# Altium schematic sheet USB.SchDoc(USB)
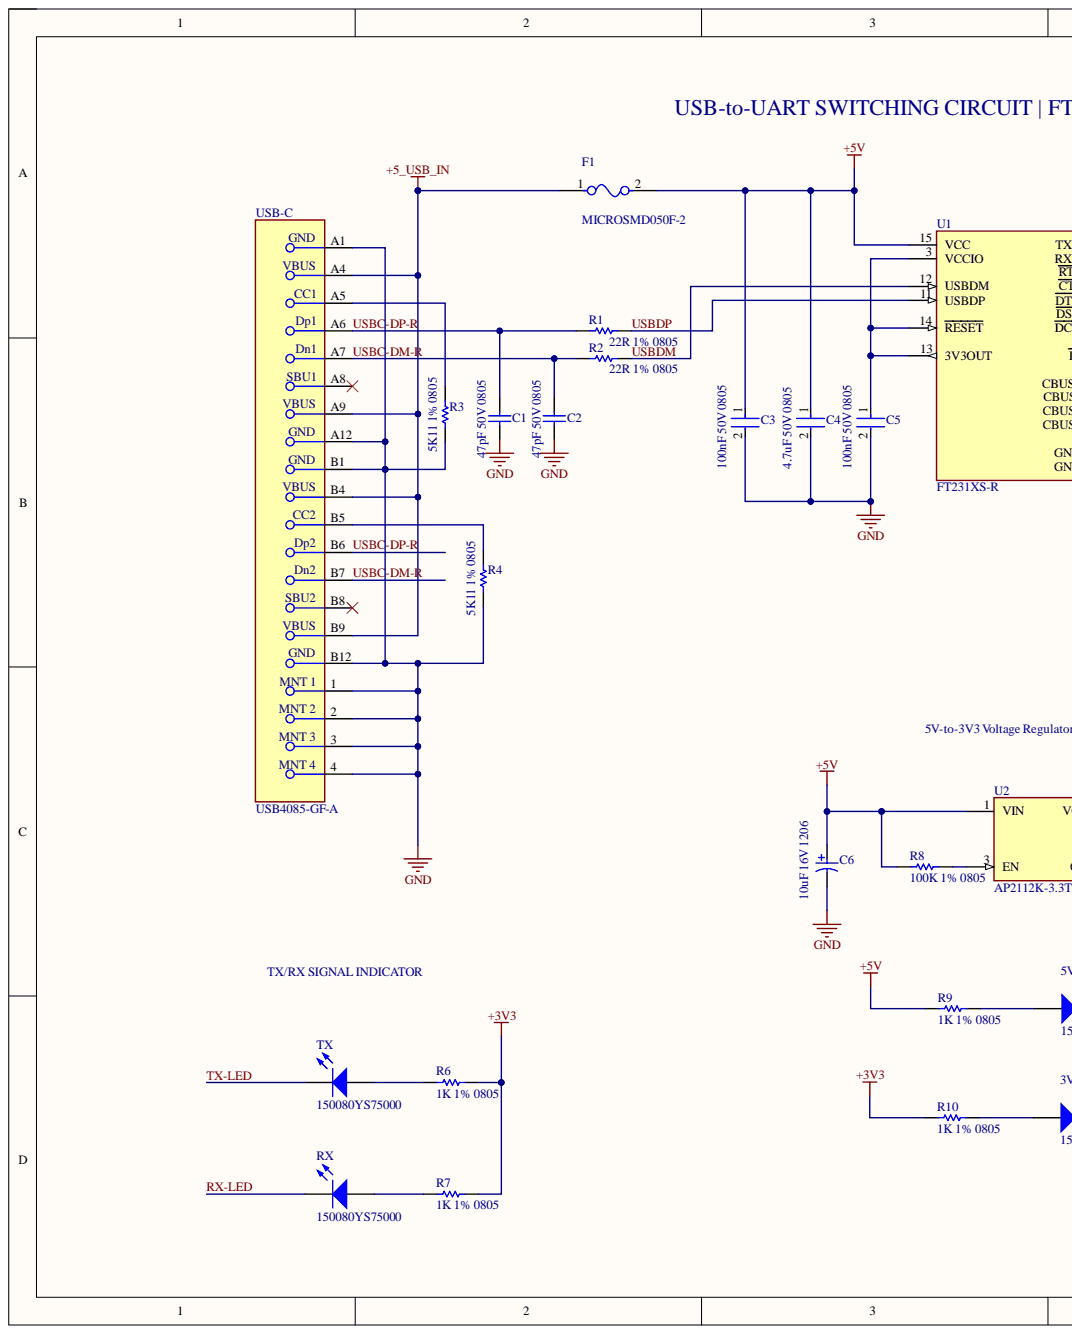
[diagram p0: USB.SchDoc, left]
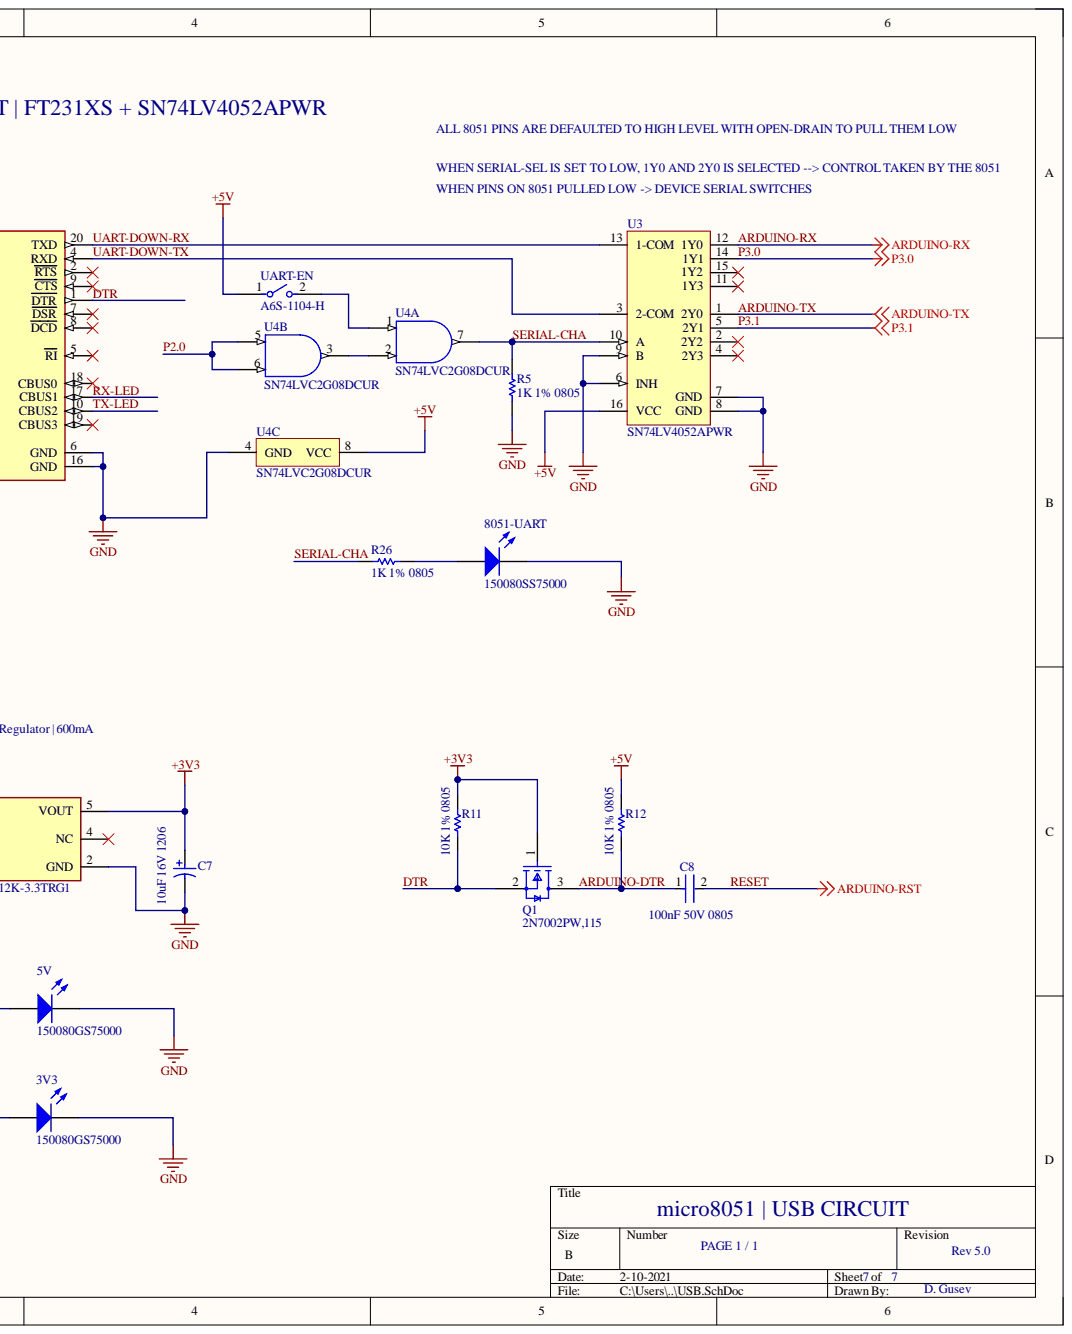
[diagram p1: USB.SchDoc, right]

## Parts
3V3  150080GS75000  [0805_A]
5V  150080GS75000  [0805_A]
8051-UART  150080SS75000  [0805_A]
C1  47pF 50V 0805  [CAPC2013X71X50NL20T25]
C2  47pF 50V 0805  [CAPC2013X71X50NL20T25]
C3  100nF 50V 0805  [FP-C0805C-DN-MFG]
C4  4.7uF 50V 0805  value 4.7uF  Capacitance: 4.7uF  Voltage Rating: 50V  [FP-C2012-125-MFG]
C5  100nF 50V 0805  [FP-C0805C-DN-MFG]
C6  10uF 16V 1206  Capacitance: 10uF  Package Reference: 1206  [KEMT-A-3216-18-2_M]
C7  10uF 16V 1206  Capacitance: 10uF  Package Reference: 1206  [KEMT-A-3216-18-2_M]
C8  100nF 50V 0805  [FP-C0805C-DN-MFG]
F1  MICROSMD050F-2  [FP-microSMD-MFG]
Q1  2N7002PW,115  [FP-SOT323-MFG]
R1  22R 1% 0805  [RESC2013X70X40LL20T20]
R2  22R 1% 0805  [RESC2013X70X40LL20T20]
R3  5K11 1% 0805  Case-EIA: 0805  [RESC0805(2012)_N]
R4  5K11 1% 0805  Case-EIA: 0805  [RESC0805(2012)_N]
R5  1K 1% 0805  [RESC2013X60X40ML20T25]
R6  1K 1% 0805  [RESC2013X60X40ML20T25]
R7  1K 1% 0805  [RESC2013X60X40ML20T25]
R8  100K 1% 0805  Tolerance: 1%  [RESC2013X60X35ML10T20]
R9  1K 1% 0805  [RESC2013X60X40ML20T25]
R10  1K 1% 0805  [RESC2013X60X40ML20T25]
R11  10K 1% 0805  PackageReference: 0805  [RESC2013X60X35NL10T20]
R12  10K 1% 0805  PackageReference: 0805  [RESC2013X60X35NL10T20]
R26  1K 1% 0805  [RESC2013X60X40ML20T25]
RX  150080YS75000  [0805_A]
TX  150080YS75000  [0805_A]
U1  FT231XS-R  [FP-SSOP-20-MFG]
U2  AP2112K-3.3TRG1  [FP-SOT25-IPC_A]
U3  SN74LV4052APWR  [PW0016A_M]
U4  SN74LVC2G08DCUR  [DCU0008A_M]  (parts A,B,C)
UART-EN  A6S-1104-H  [FP-A6S-1104-H-MFG]
USB-C  USB4085-GF-A  [FP-USB4085-GF-A-MFG]

## Nets
+3V3: C7.1 Q1.1 R6.1 R7.1 R10.2 R11.2 U2.5(VOUT)
+5_USB_IN: F1.1 USB-C.A4 USB-C.A9 USB-C.B4 USB-C.B9
+5V: C3.1 C4.1 C6.1 F1.2 R8.2 R9.2 R12.2 U1.15(VCC) U2.1(VIN) U3.16(VCC) U4.8(VCC) UART-EN.1
GND: 3V3.1 5V.1 8051-UART.1 C1.1 C2.1 C3.2 C4.2 C5.2 C6.2 C7.2 R3.1 R4.1 R5.2 U1.6(GND) U1.16(GND) U2.2(GND) U3.6(INH) U3.7(GND) U3.8(GND) U3.9(B) U4.4(GND) USB-C.1 USB-C.2 USB-C.3 USB-C.4 USB-C.A1 USB-C.A12 USB-C.B1 USB-C.B12
ARDUINO-DTR: C8.1 Q1.3 R12.1
ARDUINO-RX: U3.12(1Y0)
ARDUINO-TX: U3.1(2Y0)
DTR: Q1.2 R11.1 U1.1(DTR)
P2.0: U4.5 U4.6
P3.0: U3.14(1Y1)
P3.1: U3.5(2Y1)
RESET: C8.2
RX-LED: RX.1 U1.17(CBUS1)
SERIAL-CHA: R5.1 R26.1 U3.10(A) U4.7
TX-LED: TX.1 U1.10(CBUS2)
UART-DOWN-RX: U1.20(TXD) U3.13(1-COM)
UART-DOWN-TX: U1.4(RXD) U3.3(2-COM)
USBC-DM-R: C2.2 R2.1 USB-C.A7 USB-C.B7
USBC-DP-R: C1.2 R1.1 USB-C.A6 USB-C.B6
USBDM: R2.2 U1.12(USBDM)
USBDP: R1.2 U1.11(USBDP)
Net3V3_2: 3V3.2 R10.1
Net5V_2: 5V.2 R9.1
Net8051-UART_2: 8051-UART.2 R26.2
NetC5_1: C5.1 U1.3(VCCIO) U1.13(3V3OUT) U1.14(RESET)
NetR3_2: R3.2 USB-C.A5
NetR4_2: R4.2 USB-C.B5
NetR6_2: R6.2 TX.2
NetR7_2: R7.2 RX.2
NetR8_1: R8.1 U2.3(EN)
NetU4_1: U4.1 UART-EN.2
NetU4_2: U4.2 U4.3

Not connected: U1 2(RTS) 5(RI) 7(DSR) 8(DCD) 9(CTS) 18(CBUS0) 19(CBUS3); U2 4(NC); U3 2(2Y2) 4(2Y3) 11(1Y3) 15(1Y2); USB-C A8 B8

## Library data of the parts
3V3 5V = CMP-1426-00007-1: SMD mono-color Chip LED, WL-SMCW, Green; Manufacturer: Wuerth Elektronik; Color: Green; Component Kind: Standard; ComponentLink1Description: Manufacturer URL; ComponentLink1URL: http://www.we-online.com; ComponentLink2Description: Datasheet; ComponentLink2URL: http://katalog.we-online.com/led/datasheet/150080GS75000.pdf; Library Name: Altium Content Vault; PackageReference: 0805_A; PartNumber: 150080GS75000
8051-UART = CMP-1426-00010-1: SMD mono-color Chip LED, WL-SMCW, Super Red; Manufacturer: Wuerth Elektronik; Color: Super Red; Component Kind: Standard; ComponentLink1Description: Manufacturer URL; ComponentLink1URL: http://www.we-online.com; ComponentLink2Description: Datasheet; ComponentLink2URL: http://katalog.we-online.com/led/datasheet/150080SS75000.pdf; Library Name: Altium Content Vault; PackageReference: 0805_A; PartNumber: 150080SS75000
C1 C2 = CMP-2007-04508-1: Manufacturer: AVX Interconnect / Elco; Part Number: 08055A470JAT2A; Component Kind: Standard; Library Name: Altium Content Vault
C3 C5 C8 = CMP-2007-00279-2: CAP CER 0.1UF 50V X7R 0805; Manufacturer: KEMET; Manufacturer Part Number: C0805C104K5RACTU; Capacitance: 0.10 μF; Material: Ceramic; Temperature Coefficient: 30ppm/°C; Tolerance: 0.1; Value: 0.10 μF; Automotive: No; Category: Cap; Component Kind: Standard; ComponentLink2Description: Datasheet; ComponentLink2URL: https://content.kemet.com/datasheets/KEM_C1003_C0G_SMD.pdf; Device Class L1: Passive Components; Device Class L2: Capacitors; Device Class L3: Ceramic Capacitors; Digikey Description: CAP CER 0.1UF 50V X7R 0805; Height: 0.88mm; Ipc Land Pattern Name: CAPC200125X78; Lead Free: Yes; Library Name: Altium Content Vault; Mouser Description: Cap Ceramic 0.1uF 50V X7R 10% SMD 2.0 x 1.2 mm 125 C Paper T/R; Octopart: https://octopart.com/c0805c104k5ractu-kemet-143926; Package: C0805C-DN; RoHS: TRUE; Temperature: -55°C to +125°C; Temperature Characteristic: X7R; Temperature Range High: +125°C; Temperature Range Low: -55°C; Voltage Rating: 50 V
C4 = CMP-08246-000007-1: CAP CER 4.7UF 50V X5R 0805; Manufacturer: TDK Corporation; Manufacturer Part Number: C2012X5R1H475K125AB; Material: Ceramic; Temperature Coefficient: 15%; Tolerance: 10%; Automotive: No; Category: Cap; Component Kind: Standard; ComponentLink2Description: Datasheet; ComponentLink2URL: https://product.tdk.com/info/en/catalog/datasheets/mlcc_commercial_general_en.pdf; Device Class L1: Passive Components; Device Class L2: Capacitors; Device Class L3: Ceramic Capacitors; Digikey Description: CAP CER 4.7UF 50V X5R 0805; Height: 1.45mm; Lead Free: Yes; Library Name: Altium Content Vault; Mouser Description: Multilayer Ceramic Capacitors MLCC - SMD/SMT 0805 4.7uF 50volts X5R 10%; Octopart: https://octopart.com/c2012x5r1h475k125ab-tdk-25947720; Package: C2012-125; RoHS: TRUE; Temperature: -55°C to +85°C; Temperature Characteristic: X5R; Temperature Range High: +85°C; Temperature Range Low: -55°C
C6 C7 = CMP-2000-05173-1: Capacitor, Tantalum, 10 uF, +/- 10%, 16 V, -55 to 125 degC, 2-Pin SMD (1206), RoHS, Tape and Reel; Manufacturer: Kemet; Case Style: Molded; DCL: 1.6uA; DF: 8%; ESR: 7ohm; Insulation: NotRequired; Lead Spacing (nom): NotRequiredmm; Polarity: Polar; Product Depth (mm): 1.6mm; Product Length (mm): 3.2mm; Voltage: 16VDC; Case Code: A; Component Kind: Standard; Construction: SMTChip; Datasheet URL: http://www.farnell.com/datasheets/1824869.pdf; Datasheet Version: Apr-14; Failure Rate: NotRequired; Length W/Weld: NotRequiredmm; Library Name: Altium Content Vault; Manufacturer URL: http://www.kemet.com/; Mounting Style: SurfaceMount; Operating Temp Range: -55Cto125C; Package / Case: 3216-18; Package Description: 2-Pin Surface Mount Device, Body 3.2 x 1.6 mm; Packaging: TapeandReel; Product Diameter (mm): NotRequiredmm; Product Height (mm): 1.6mm; Seal: NotRequired; Seated Plane Height: NotRequiredmm; Tolerance (+ or -): 10%; Wire Form: NotRequired
F1 = CMP-08606-000015-1: PTC RESET FUSE 13.2V 500MA 1210; Manufacturer: Littelfuse; Current Rating: 40A; Hold Current: 0.5A; Resistance: 0.25-0.9Ω; Trip Current: 1A; Trip Time: 0.05s; Value: 0.25-0.9Ω; Ambient Temperature Range High: +85°C; Ambient Temperature Range Low: -40°C; Automotive: No; Category: Fuse; Component Kind: Standard; ComponentLink2Description: Datasheet; ComponentLink2URL: https://www.littelfuse.com/~/media/electronics/datasheets/resettable_ptcs/littelfuse_ptc_microsmd_datasheet.pdf.pdf; Device Class L1: Circuit Protection; Device Class L2: PTC Resettable Fuses; Device Class L3: unset; Digikey Description: PTC RESET FUSE 13.2V 500MA 1210; Height: 0.62mm; Lead Free: Yes; Library Name: Altium Content Vault; Manufacturer Part Number: MICROSMD050F-2; Octopart: https://octopart.com/microsmd050f-2-littelfuse-74214982; Package: microSMD; RoHS: TRUE; Temperature: to +85°C; Temperature Range High: +85°C; Voltage Rating: 13.2V
Q1 = CMP-14409-000019-1: MOSFET N-CH 60V 0.31A SOT323; Component Kind: Standard; Library Name: Altium Content Vault
R1 R2 = CMP-2001-00523-1: Manufacturer: Panasonic; Part Number: ERJ6ENF22R0V; Component Kind: Standard; Library Name: Altium Content Vault
R3 R4 = CMP-1013-00591-1: 5K11 0.125W 1% 0805 (2012 Metric)  SMD; Case-Metric: 2012; Value: 5110.00 Ohm; Component Kind: Standard; Library Name: Altium Content Vault; Power: 0.125 W; Technology: SMT; Tolerance: 1 %
R5-R7 R9 R10 R26 = CMP-2001-00590-1: Manufacturer: Panasonic; Part Number: ERA6AEB123V; Component Kind: Standard; Library Name: Altium Content Vault
R8 = CMP-2100-03668-1: Chip Resistor, 100 KOhm, +/- 1%, 0.125 W, -55 to 155 degC, 0805 (2012 Metric), RoHS, Tape and Reel; Manufacturer: Yageo; Max Power Dissipation: 125mW; Temperature Coefficient: 100ppm/degreesC; Voltage Rating: 150V; Case Code (Imperial): 0805; Case Code (Metric): 2012; Case/Package: 0805; Component Kind: Standard; Composition: ThickFilm; Datasheet URL: http://www.yageo.com/NewPortal/yageodocoutput?fileName=/pdf/R-Chip/PYu-RC_Group_51_RoHS_L_5.pdf; Datasheet Version: Rev. 5, 10/2016; Depth: 1.25mm; Height: 0.024inch; Lead Free: LeadFree; Lead Pitch: 0.4mm; Length: 2mm; Library Name: Altium Content Vault; Manufacturer URL: http://www.yageo.com/; Max Operating Temperature: 155degC; Military Standard: Not; Min Operating Temperature: -55degC; Number of Pins: 2; Number of Terminations: 2; Package Description: 2-Pin Surface Mounted Device, Body 2 x 1.25 mm; Package Quantity: 1; Package Reference: 0805; Packaging: TapeandReel; Power Rating: 125mW; REACH SVHC: Unknown; Resistance: 100KOhm; RoHSCompliant: true; Termination: SMD/SMT; Voltage Rating (DC): 150V; Width: 0.049inch
R11 R12 = CMP-1659-00013-4: Chip Resistor, 10 KOhm, +/- 1%, 0.125 W, -55 to 155 degC, 0805 (2012 Metric), RoHS, Tape and Reel; Manufacturer: Yageo; Voltage Rating: 150 V; Component Kind: Standard; ComponentLink1Description: Manufacturer URL; ComponentLink1URL: http://www.yageo.com/; ComponentLink2Description: Datasheet; ComponentLink2URL: http://www.yageo.com/documents/recent/PYu-RC_Group_51_RoHS_L_04.pdf; DatasheetVersion: Rev. 4, 02/2016; Height: 0.5 mm; Length: 2 mm; Library Name: Altium Content Vault; Max Operating Temperature: 155 degC; Min Operating Temperature: -55 degC; Mount: Surface Mount; Mounting Technology: Surface Mount; Package Quantity: 5000; PackageDescription: 2-Pin Surface Mounted Device, Body 2 x 1.25 mm; Packaging: Tape and Reel; Pins: 2; REACH SVHC: No SVHC; RoHSCompliant: true
RX TX = CMP-1426-00012-1: SMD mono-color Chip LED, WL-SMCW, Yellow; Manufacturer: Wuerth Elektronik; Color: Yellow; Component Kind: Standard; ComponentLink1Description: Manufacturer URL; ComponentLink1URL: http://www.we-online.com; ComponentLink2Description: Datasheet; ComponentLink2URL: http://katalog.we-online.com/led/datasheet/150080YS75000.pdf; Library Name: Altium Content Vault; PackageReference: 0805_A; PartNumber: 150080YS75000
U1 = CMP-0248-00024-3: IC USB SERIAL FULL UART 20SSOP; Data Rate: 12Mbps; Max Supply Voltage: 5.5V; Min Supply Voltage: 2.97V; Number of Channels: 1; Operating Supply Current: 8mA; Operating Supply Voltage: 5V; Case/Package: SSOP; Component Kind: Standard; Height: 0.059inch; Interface: UART; Length: 8.74mm; Library Name: Altium Content Vault; Max Operating Temperature: 85°C; Min Operating Temperature: -40°C; Mount: Surface Mount; Number of Pins: 20; Package Quantity: 2000; Packaging: Tape and Reel; Radiation Hardening: No; Weight: 0.016oz; Width: 0.157inch
U2 = CMP-12756-000035-1: IC REG LINEAR 3.3V 600MA SOT25; Accuracy: 1.5%; Dropout Voltage: 250mV; Max Input Voltage: 6V; Min Input Voltage: 2.5V; Number of Outputs: 1; Output Type: Fixed; Polarity: Positive; Quiescent Current: 55uA; Case/Package: SOT-23-5; Component Kind: Standard; Height: 1.3mm; Lead Free: Lead Free; Length: 3.1mm; Library Name: Altium Content Vault; Max Operating Temperature: 85°C; Max Output Current: 600mA; Min Operating Temperature: -40°C; Mount: Surface Mount; Number of Pins: 5; Number of Regulators: 1; Output Current: 600mA; Output Voltage: 3.3V; Package Quantity: 1; Packaging: Tape and Reel; Width: 1.7mm
U3 = CMP-0802-00041-4: Dual 4-Channel Analog Multiplexer/Demultiplexer, PW0016A, LARGE T&R; Manufacturer: Texas Instruments; Bandwidth(Max)(MHz): 50; Configuration: 2 X 1：4 MUX; Crosstalk: Yes; Output Type: CMOS; Rating: Catalog; Ron(Max)(Ohms): 75; Ron(Typ)(Ohms): 25; VCC(Max)(V): 5.5; VCC(Min)(V): 2; Code_JEDEC: MO-153; Component Kind: Standard; DatasheetVersion: SCLS429J; Generic Part Number: SN74LV4052A; Library Name: Altium Content Vault; Operating Temperature Range(C): -40 to 85; PackageReference: PW0016A; PackageVersion: http://www.ti.com/lit/ml/mpds361/mpds361.pdf
U4 = CMP-0859-00670-3: Dual 2-Input Positive-AND Gate, DCU0008A, LARGE T&R; Manufacturer: Texas Instruments; 3-State Output: No; Bits(#): 2; F @ Nom Voltage(Max)(Mhz): 150; Gate Type: AND; ICC @ Nom Voltage(Max)(mA): 0.01; Input Type: CMOS/TTL; Logic: True; Output Drive (IOL/IOH)(Max)(mA): 32/-32; Output Type: CMOS; Rating: Catalog; Technology Family: LVC; tpd @ Nom Voltage(Max)(ns): 9.8,5.8,5.3,4.8; VCC(Max)(V): 5.5; VCC(Min)(V): 1.65; Voltage(Nom)(V): 1.8,2.5,3.3,5; Component Kind: Standard; DatasheetVersion: SCES198M; Generic Part Number: SN74LVC2G08; Library Name: Altium Content Vault; Operating Temperature Range(C): -40 to 125,-40 to 85; PackageReference: DCU0008A; Schmitt Trigger: No; Sub-Family: AND Gate
UART-EN = CMP-08827-000005-1: SWITCH SLIDE DIP SPST 25MA 24V; Manufacturer: Omron Electronics Inc-EMC Div; Contact Resistance: 200mΩ; Electromechanical Life: 1000Cycles; Number Of Circuits: 1; Standoff Height: 0mm; Throw Configuration: SPST-NO; Ambient Temperature Range High: +70°C; Ambient Temperature Range Low: -20°C; Automotive: No; Category: Switch; Component Kind: Standard; ComponentLink2Description: Datasheet; ComponentLink2URL: https://omronfs.omron.com/en_US/ecb/products/pdf/en-a6s.pdf; Contact Current Rating: 25mA; Device Class L1: Electromechanical; Device Class L2: Switches; Device Class L3: DIP Switches; Digikey Description: SWITCH SLIDE DIP SPST 25MA 24V; Height: 3.95mm; Lead Free: Yes; Library Name: Altium Content Vault; Manufacturer Part Number: A6S-1104-H; Mount: Surface Mount; Mouser Description: DIP Switches / SIP Switches Dip Switch; Octopart: https://octopart.com/a6s-1104-h-omron-55410938; Package: 0; RoHS: TRUE; Temperature: -20°C to +70°C; Temperature Range High: +70°C; Temperature Range Low: -20°C; Voltage Rating Dc: 24V
USB-C = CMP-196119-000001-1: CONN RCPT USB2.0 TYPEC 16POS; Manufacturer: Global Connector Technology; Conn Orientation: Right Angle; Current Rating: 4.25A; Is Female: yes; Number Of Contacts: 16; Pitch: 0.85mm; Shielding: true; Voltage Rating: 20V; Automotive: No; Category: Conn; Component Kind: Standard; ComponentLink2Description: Datasheet; ComponentLink2URL: https://gct.co/files/drawings/usb4085.pdf; Contact Material: Gold,Nickel; Device Class L1: Connectors; Device Class L2: USB Connectors; Device Class L3: unset; Digikey Description: CONN RCPT USB2.0 TYPEC 16POS; Height: 3.66mm; Lead Free: Yes; Library Name: Altium Content Vault; Manufacturer Part Number: USB4085-GF-A; Octopart: https://octopart.com/usb4085-gf-a-global+connector+technology-94773699; Package: 0; RoHS: TRUE; Temperature: -40°C to +85°C; Temperature Range High: +85°C; Temperature Range Low: -40°C; Usb Standard: USB 2.0
